# Altium schematic sheet XBR401-03-082520.SCHDOC
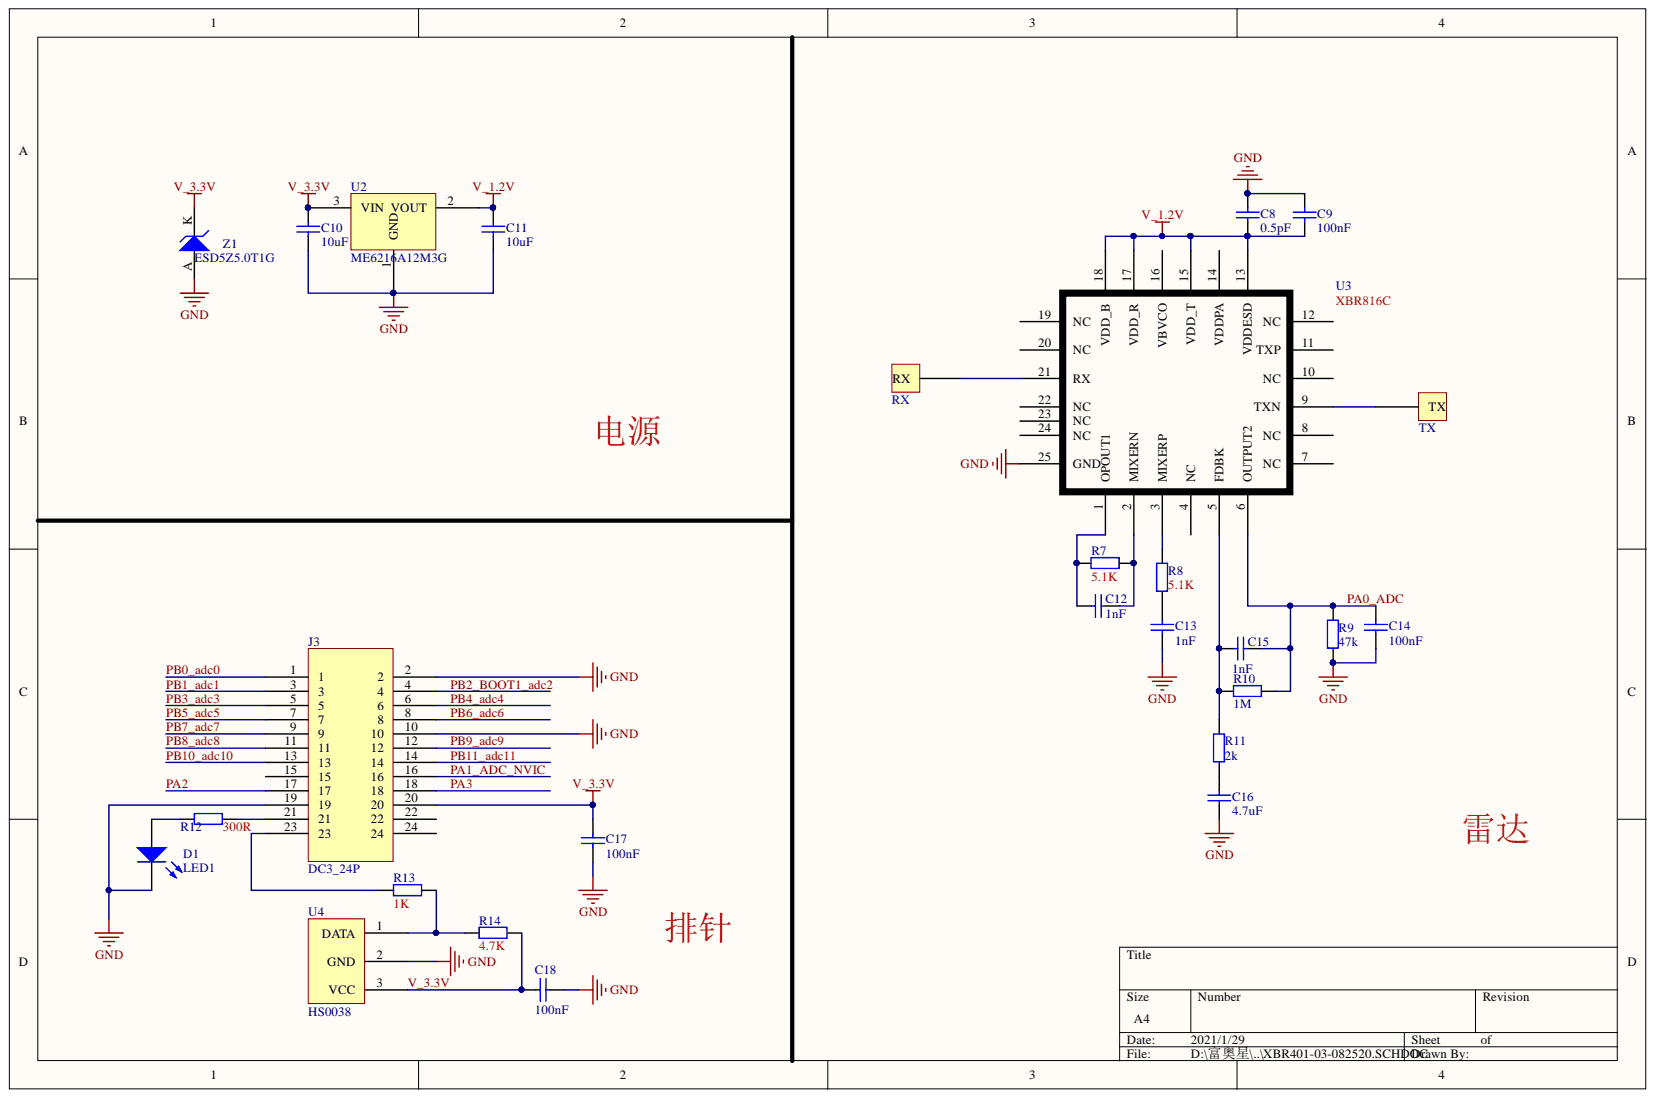
[diagram p0: XBR401-03-082520.SCHDOC]

## Parts
C8  0.5pF  [C 0402]
C9  100nF  [C 0402]
C10  10uF  [C 0402]
C11  10uF  [C 0402]
C12  1nF  [C 0402]
C13  1nF  [C 0402]
C14  100nF  [C 0402]
C15  1nF  [C 0402]
C16  4.7uF  [C 0402]
C17  100nF  [C 0402]
C18  100nF  [C 0402]
D1  LED1  [LED1]
J3  DC3_24P  [CON2.0-12X2]
R7  5.1K  [R 0402]
R8  5.1K  [R 0402]
R9  47k  [R 0402]
R10  1M  [R 0402]
R11  2k  [R 0402]
R12  300R  [R 0402]
R13  1K  [R 0402]
R14  4.7K  [R 0402]
T1  RX  [RX]
T2  TX  [TX]
U2  ME6216A12M3G  [SOT23_3]
U3  XBR816C  [QFN24-1]
U4  HS0038  [HS0038]
Z1  ESD5Z5.0T1G  [SOD323]

## Nets
GND: C8.2 C9.2 C10.2 C11.2 C13.1 C14.2 C16.2 C17.2 C18.2 D1.2 J3.2 J3.10 J3.19 R9.2 U2.1 U3.25(GND) U4.2 Z1.A
V_1.2V: C8.1 C9.1 C11.1 U2.2 U3.13(VDDESD) U3.15(VDD_T) U3.17(VDD_R) U3.18(VDD_B)
PA0_ADC: C14.1 C15.1 R9.1 R10.1 U3.6(OUTPUT2)
PA1_ADC_NVIC: J3.16
PA2: J3.17
PA3: J3.18
PB0_adc0: J3.1
PB1_adc1: J3.3
PB2_BOOT1_adc2: J3.4
PB3_adc3: J3.5
PB4_adc4: J3.6
PB5_adc5: J3.7
PB6_adc6: J3.8
PB7_adc7: J3.9
PB8_adc8: J3.11
PB9_adc9: J3.12
PB10_adc10: J3.13
PB11_adc11: J3.14
V_3.3V: C10.1 C17.1 C18.1 J3.20 R14.2 U2.3 U4.3 Z1.K
NetC12_1: C12.1 R7.1 U3.2(MIXERN)
NetC12_2: C12.2 R7.2 U3.1(OPOUT1)
NetC13_2: C13.2 R8.2
NetC15_2: C15.2 R10.2 R11.2 U3.5(FDBK)
NetC16_1: C16.1 R11.1
NetD1_1: D1.1 R12.2
NetJ3_21: J3.21 R12.1
NetJ3_23: J3.23 R13.1
NetR8_1: R8.1 U3.3(MIXERP)
NetR13_2: R13.2 R14.1 U4.1
NetT1_1: T1.1 U3.21(RX)
NetT2_1: T2.1 U3.9(TXN)

Not connected: J3 15 22 24; U3 4(NC) 7(NC) 8(NC) 10(NC) 11(TXP) 12(NC) 14(VDDPA) 16(VBVCO) 19(NC) 20(NC) 22(NC) 23(NC) 24(NC)

## Library data of the parts
C8-C18 = Cap: Capacitor; Component Kind: Standard; LatestRevisionDate: 17-Jul-2002; LatestRevisionNote: Re-released for DXP Platform.; Library Name: Miscellaneous Devices.IntLib; PackageDescription: Radial Cap, Thru-Hole; 2 Leads; 0.3 in Pin Spacing; PackageReference: RAD-0.3; Published: 8-Jun-2000; Publisher: Altium Limited
  C8: Value: 0.5pF
  C9 C14 C17 C18: Value: 100nF
  C10 C11: Value: 10uF
  C12 C13 C15: Value: 1nF
  C16: Value: 4.7uF
D1 = LED1: Typical RED GaAs LED; Component Kind: Standard; LatestRevisionDate: 08-Jul-2005; LatestRevisionNote: Mech Layer 1 removed.; Library Name: Miscellaneous Devices.IntLib; PackageDescription: LED; 2 Leads; PackageReference: LED-1; Published: 8-Jun-2000; Simulation: LED1; Publisher: Altium Limited
R7-R14 = Res2: Resistor; Component Kind: Standard; LatestRevisionDate: 17-Jul-2002; LatestRevisionNote: Re-released for DXP Platform.; Library Name: Miscellaneous Devices.IntLib; PackageDescription: Axial Device, Thru-Hole; 2 Leads; 0.4 in Pin Spacing; PackageReference: AXIAL-0.4; Published: 8-Jun-2000; Publisher: Altium Limited
  R7 R8: Value: 5.1K
  R9: Value: 47k
  R10: Value: 1M
  R11: Value: 2k
  R12: Value: 300R
  R13: Value: 1K
  R14: Value: 4.7K
U2 = SSP6206: Component Kind: Standard; Library Name: Schlib1.SchLib
U3 = XBR1000_24_1: Component Kind: Standard; Library Name: Schlib1.SchLib
Z1 = D Zener: Zener Diode; Component Kind: Standard; LatestRevisionDate: 17-Jul-2002; LatestRevisionNote: Re-released for DXP Platform.; Library Name: Miscellaneous Devices.IntLib; PackageDescription: Diode, Thru-Hole; 2 Leads; 0.7 in Pin Spacing; PackageReference: DIODE-0.7; Published: 8-Jun-2000; Simulation: ZENER; Publisher: Altium Limited
J3 = DC3_24P: Component Kind: Standard; Library Name: Schlib1.SchLib
T1 = RX: Component Kind: Standard; Library Name: Schlib1.SchLib
T2 = TX: Component Kind: Standard; Library Name: Schlib1.SchLib
U4 = HS0038: Component Kind: Standard; Library Name: Schlib1.SchLib
